# Altium schematic sheet Microcontroller.SchDoc(Microcontroller)
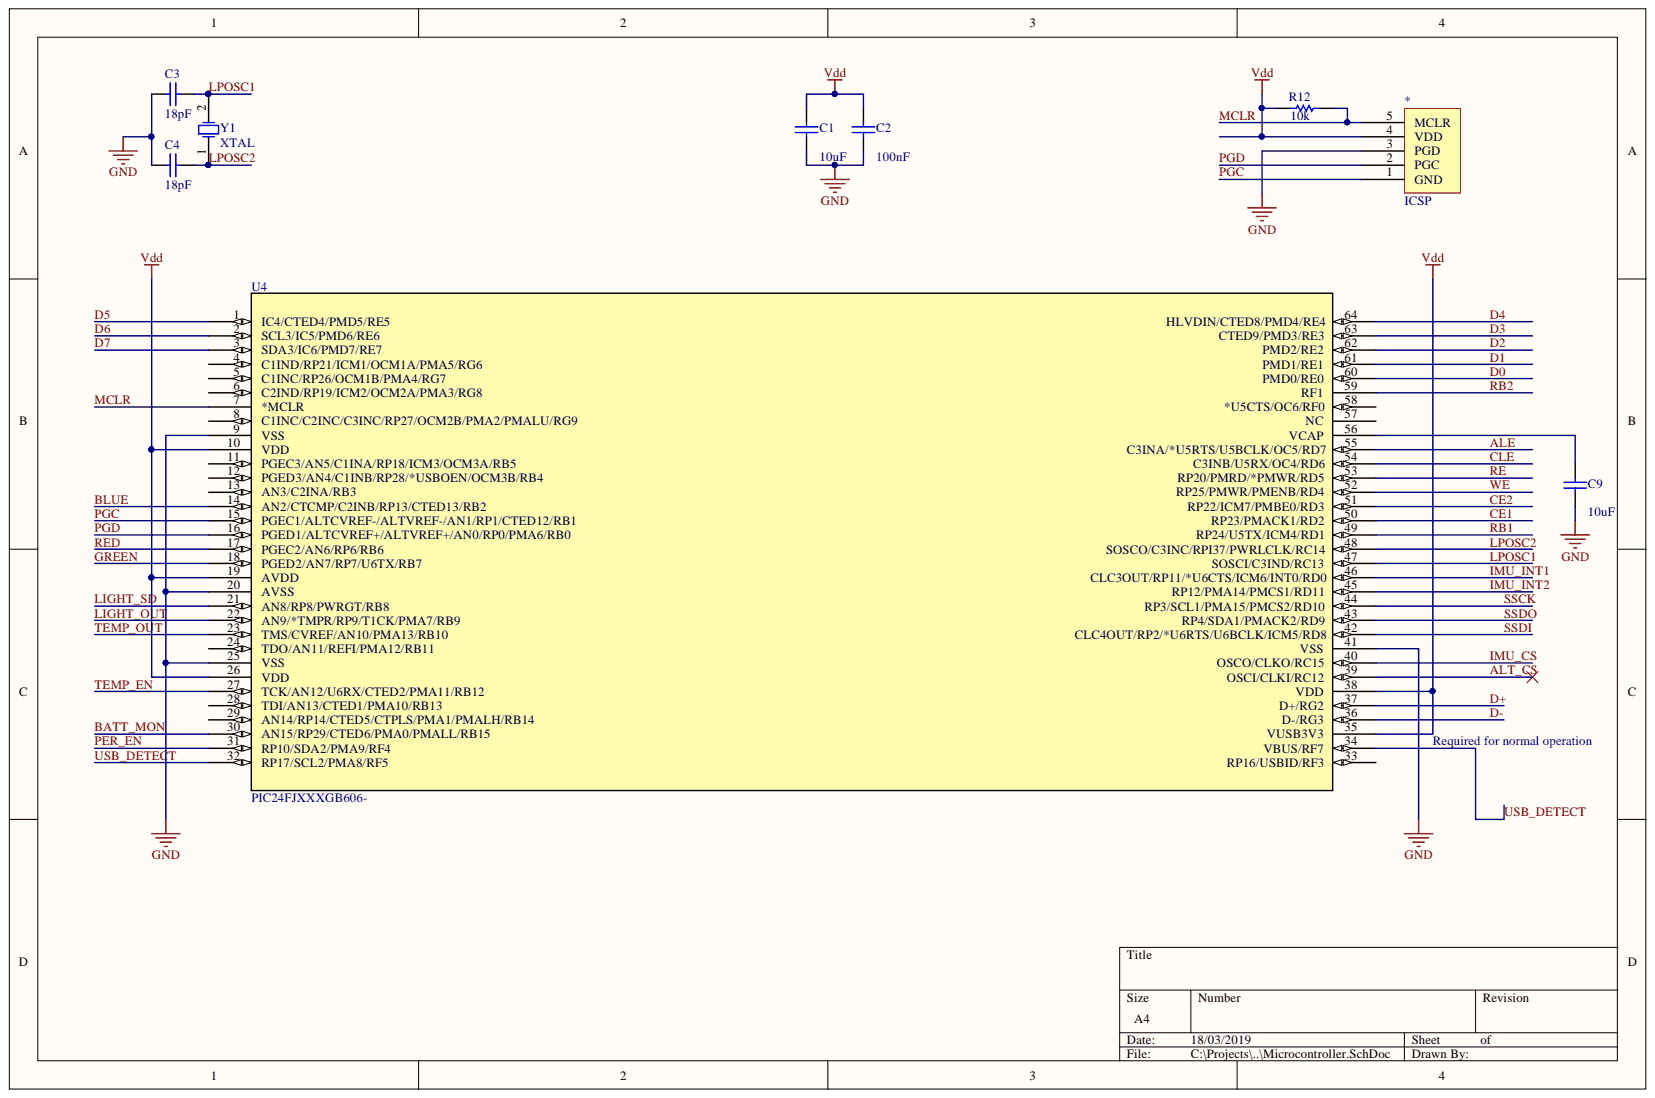
[diagram p0: Microcontroller.SchDoc]

## Parts
*  ICSP  [ICSP]
C1  10uF  [1608[0603]]
C2  100nF  [0402c]
C3  18pF  [0402c]
C4  18pF  [0402c]
C9  10uF  [1608[0603]]
R12  10k  [0402]
U4  PIC24FJXXXGB606-  [TQFP64_10x10MC]  (value not drawn)
Y1  XTAL  [XTAL]

## Nets
GND: *.3(PGD) C1.1 C2.1 C3.2 C4.2 C9.1 U4.9(VSS) U4.20(AVSS) U4.25(VSS) U4.41(VSS)
Vdd: *.4(VDD) C1.2 C2.2 R12.1 U4.10(VDD) U4.19(AVDD) U4.26(VDD) U4.35(VUSB3V3) U4.38(VDD)
ALE: U4.55(C3INA/*U5RTS/U5BCLK/OC5/RD7)
ALT_CS: U4.39(OSCI/CLKI/RC12)
BATT_MON: U4.30(AN15/RP29/CTED6/PMA0/PMALL/RB15)
BLUE: U4.14(AN2/CTCMP/C2INB/RP13/CTED13/RB2)
CE1: U4.50(RP23/PMACK1/RD2)
CE2: U4.51(RP22/ICM7/PMBE0/RD3)
CLE: U4.54(C3INB/U5RX/OC4/RD6)
D0: U4.60(PMD0/RE0)
D1: U4.61(PMD1/RE1)
D2: U4.62(PMD2/RE2)
D3: U4.63(CTED9/PMD3/RE3)
D4: U4.64(HLVDIN/CTED8/PMD4/RE4)
D5: U4.1(IC4/CTED4/PMD5/RE5)
D6: U4.2(SCL3/IC5/PMD6/RE6)
D7: U4.3(SDA3/IC6/PMD7/RE7)
D+: U4.37(D+/RG2)
D-: U4.36(D-/RG3)
GREEN: U4.18(PGED2/AN7/RP7/U6TX/RB7)
IMU_CS: U4.40(OSCO/CLKO/RC15)
IMU_INT1: U4.46(CLC3OUT/RP11/*U6CTS/ICM6/INT0/RD0)
IMU_INT2: U4.45(RP12/PMA14/PMCS1/RD11)
LIGHT_OUT: U4.22(AN9/*TMPR/RP9/T1CK/PMA7/RB9)
LIGHT_SD: U4.21(AN8/RP8/PWRGT/RB8)
LPOSC1: C3.1 U4.47(SOSCI/C3IND/RC13) Y1.2
LPOSC2: C4.1 U4.48(SOSCO/C3INC/RPI37/PWRLCLK/RC14) Y1.1
MCLR: *.5(MCLR) R12.2 U4.7(*MCLR)
PER_EN: U4.31(RP10/SDA2/PMA9/RF4)
PGC: *.1(GND) U4.15(PGEC1/ALTCVREF-/ALTVREF-/AN1/RP1/CTED12/RB1)
PGD: *.2(PGC) U4.16(PGED1/ALTCVREF+/ALTVREF+/AN0/RP0/PMA6/RB0)
RB1: U4.49(RP24/U5TX/ICM4/RD1)
RB2: U4.59(RF1)
RE: U4.53(RP20/PMRD/*PMWR/RD5)
RED: U4.17(PGEC2/AN6/RP6/RB6)
SSCK: U4.44(RP3/SCL1/PMA15/PMCS2/RD10)
SSDI: U4.42(CLC4OUT/RP2/*U6RTS/U6BCLK/ICM5/RD8)
SSDO: U4.43(RP4/SDA1/PMACK2/RD9)
TEMP_EN: U4.27(TCK/AN12/U6RX/CTED2/PMA11/RB12)
TEMP_OUT: U4.23(TMS/CVREF/AN10/PMA13/RB10)
USB_DETECT: U4.32(RP17/SCL2/PMA8/RF5) U4.34(VBUS/RF7)
WE: U4.52(RP25/PMWR/PMENB/RD4)
NetC9_2: C9.2 U4.56(VCAP)

Not connected: U4 4(C1IND/RP21/ICM1/OCM1A/PMA5/RG6) 5(C1INC/RP26/OCM1B/PMA4/RG7) 6(C2IND/RP19/ICM2/OCM2A/PMA3/RG8) 8(C1INC/C2INC/C3INC/RP27/OCM2B/PMA2/PMALU/RG9) 11(PGEC3/AN5/C1INA/RP18/ICM3/OCM3A/RB5) 12(PGED3/AN4/C1INB/RP28/*USBOEN/OCM3B/RB4) 13(AN3/C2INA/RB3) 24(TDO/AN11/REFI/PMA12/RB11) 28(TDI/AN13/CTED1/PMA10/RB13) 29(AN14/RP14/CTED5/CTPLS/PMA1/PMALH/RB14) 33(RP16/USBID/RF3) 57(NC) 58(*U5CTS/OC6/RF0)

## Library data of the parts
* = ICSP: Programming connection; Manufacturer Part Number 1: Not placed; Library Name: AXG2_v1.SCHLIB; Component Kind: Standard
C1 C9 = Cap: Capacitor; Manufacturer Part Number 1: 10uF 10v X5R or better e.g. GRM188R61A106KE69J; Value: 10uF; Supplier Part Number 1: 490-14372-2-ND; Supplier 1: Digi-key; Simulation: CAP; Signal Integrity: Cap; Published: 8-Jun-2000; PackageReference: 1608{0603}; PackageDescription: Radial Cap, Thru-Hole; 2 Leads; 0.3 in Pin Spacing; Library Name: Miscellaneous Devices.IntLib; LatestRevisionNote: Re-released for DXP Platform.; LatestRevisionDate: 17-Jul-2002; Component Kind: Standard; Publisher: Altium Limited
C2 = Cap: Capacitor; Manufacturer Part Number 1: 100nF 16v X7R or better e.g. GRM155R71C104MA88D; Value: 100nF; Supplier Part Number 1: GRM155R71C104MA88D-ND; Supplier 1: Digi-key; Simulation: CAP; Signal Integrity: Cap; Published: 8-Jun-2000; PackageReference: 0402; PackageDescription: Radial Cap, Thru-Hole; 2 Leads; 0.3 in Pin Spacing; Library Name: Miscellaneous Devices.IntLib; LatestRevisionNote: Re-released for DXP Platform.; LatestRevisionDate: 17-Jul-2002; Component Kind: Standard; Publisher: Altium Limited
C3 C4 = Cap: Capacitor; Manufacturer Part Number 1: 18pF 50v C0G/NPO or better e.g. GRM1555C1H180JA01J; Value: 18pF; Supplier Part Number 1: 490-14582-2-ND; Supplier 1: Digi-key; Simulation: CAP; Signal Integrity: Cap; Published: 8-Jun-2000; PackageReference: 0402; PackageDescription: Radial Cap, Thru-Hole; 2 Leads; 0.3 in Pin Spacing; Library Name: Miscellaneous Devices.IntLib; LatestRevisionNote: Re-released for DXP Platform.; LatestRevisionDate: 17-Jul-2002; Component Kind: Standard; Publisher: Altium Limited
R12 = Res1: Resistor; Manufacturer Part Number 1: 10k, Generic e.g. CRCW040210K0JNEDC; Value: 10k; Supplier Part Number 1: 541-3958-2-ND; Supplier 1: Digi-key; Simulation: RESISTOR; Signal Integrity: Res; Published: 8-Jun-2000; PackageDescription: Axial Device, Thru-Hole; 2 Leads; 0.3 in Pin Spacing; Library Name: Miscellaneous Devices.IntLib; LatestRevisionNote: Re-released for DXP Platform.; LatestRevisionDate: 17-Jul-2002; Component Kind: Standard; Publisher: Altium Limited
U4 = PIC24FJXXXGB606-: Imported; Manufacturer Part Number 1: PIC24FJ1024GB606-I/PT; Manufacturer_Part_Number: pic24fjxxxgb606-x_pt; Vendor: Microchip; Value: Value; Type: Type; Supplier Part Number 1: PIC24FJ1024GB606-I/PT-ND; Supplier 1: Digi-Key; RefDes: RefDes; Library Name: 2018-02-14_09-51-14.SchLib; Component Kind: Standard
Y1 = XTAL: 32kHz Crystal Oscillator; Manufacturer Part Number 1: ECS-.327-12.5-34R-TR; Value: ECS-.327-9-34R-TR; Supplier Part Number 1: XC2124CT-ND; Supplier 1: Digi-Key; Published: 8-Jun-2000; PackageReference: XTAL; PackageDescription: Crystal, Thru-Hole; 2 Leads; Body 3.1 x 8.2 mm (Dia.xH); Library Name: Miscellaneous Devices.IntLib; LatestRevisionNote: Re-released for DXP Platform.; LatestRevisionDate: 17-Jul-2002; Component Kind: Standard; Publisher: Altium Limited
